# Altium schematic sheet schematic.SchDoc
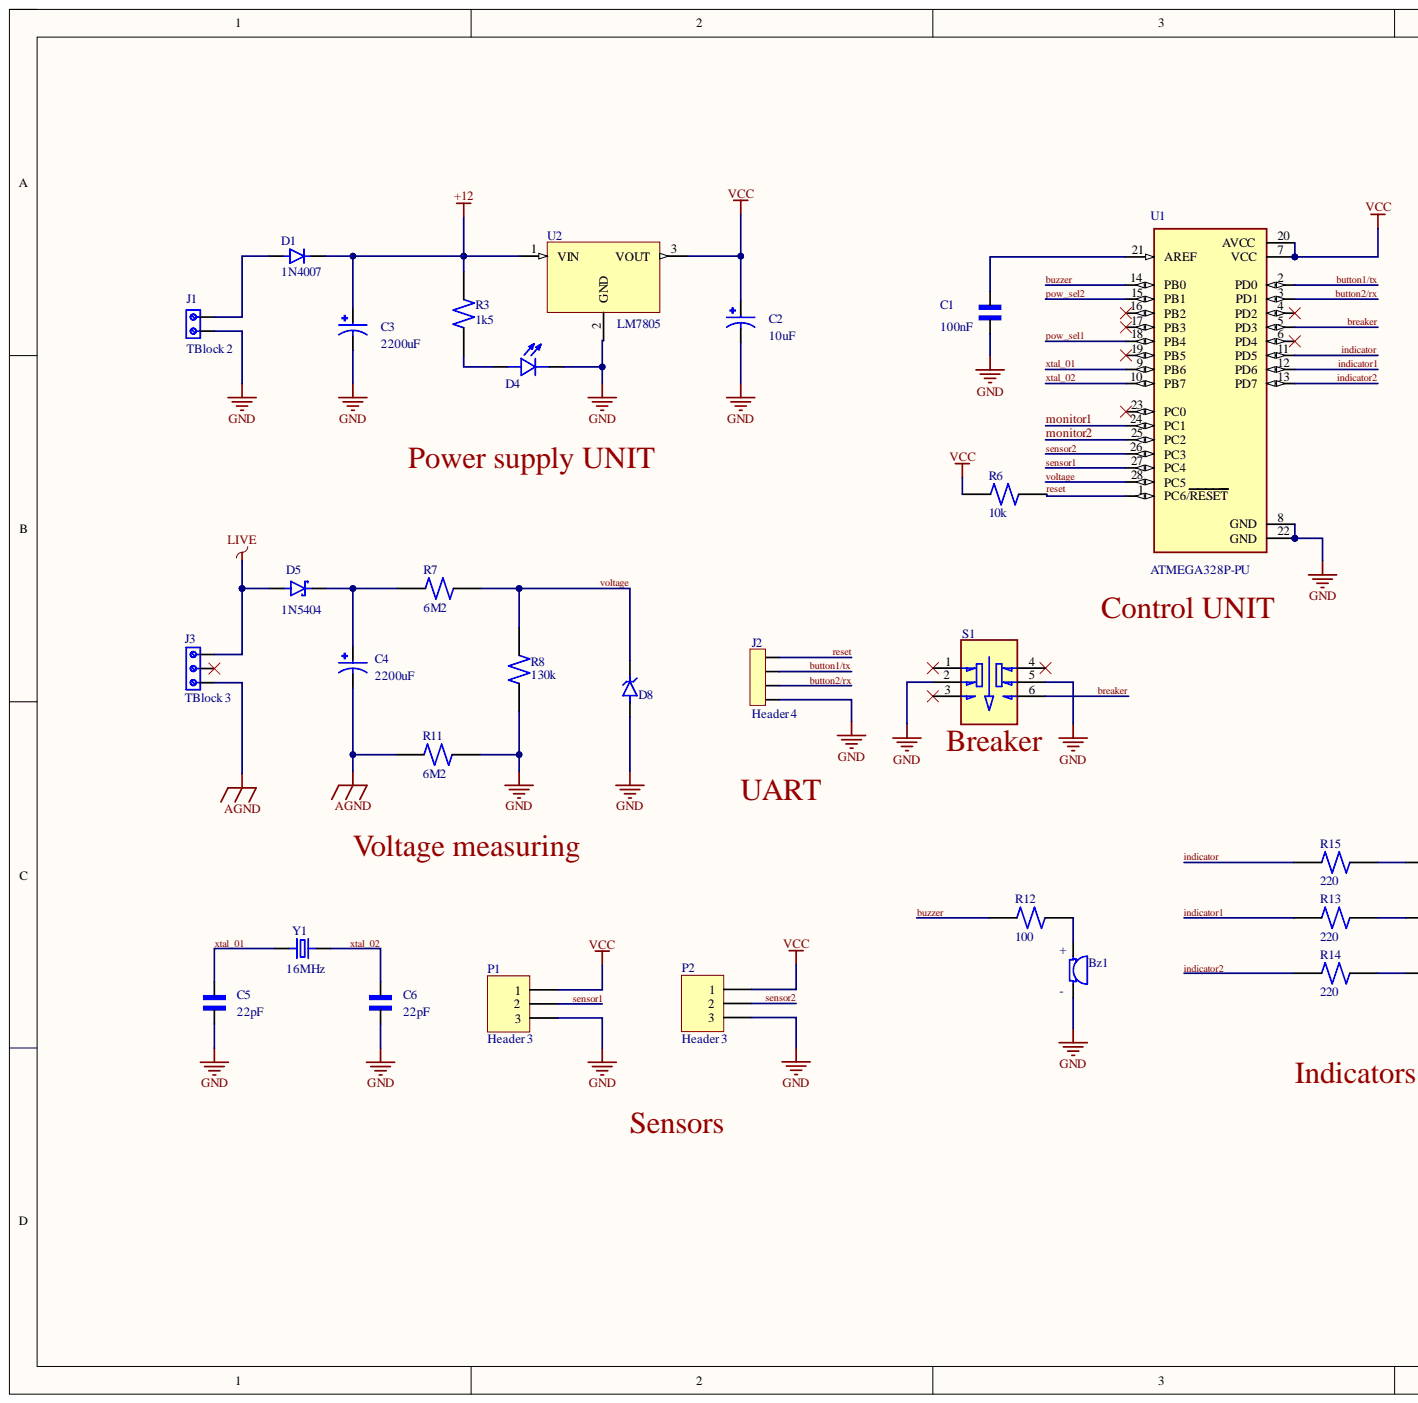
[diagram p0: schematic.SchDoc, left]
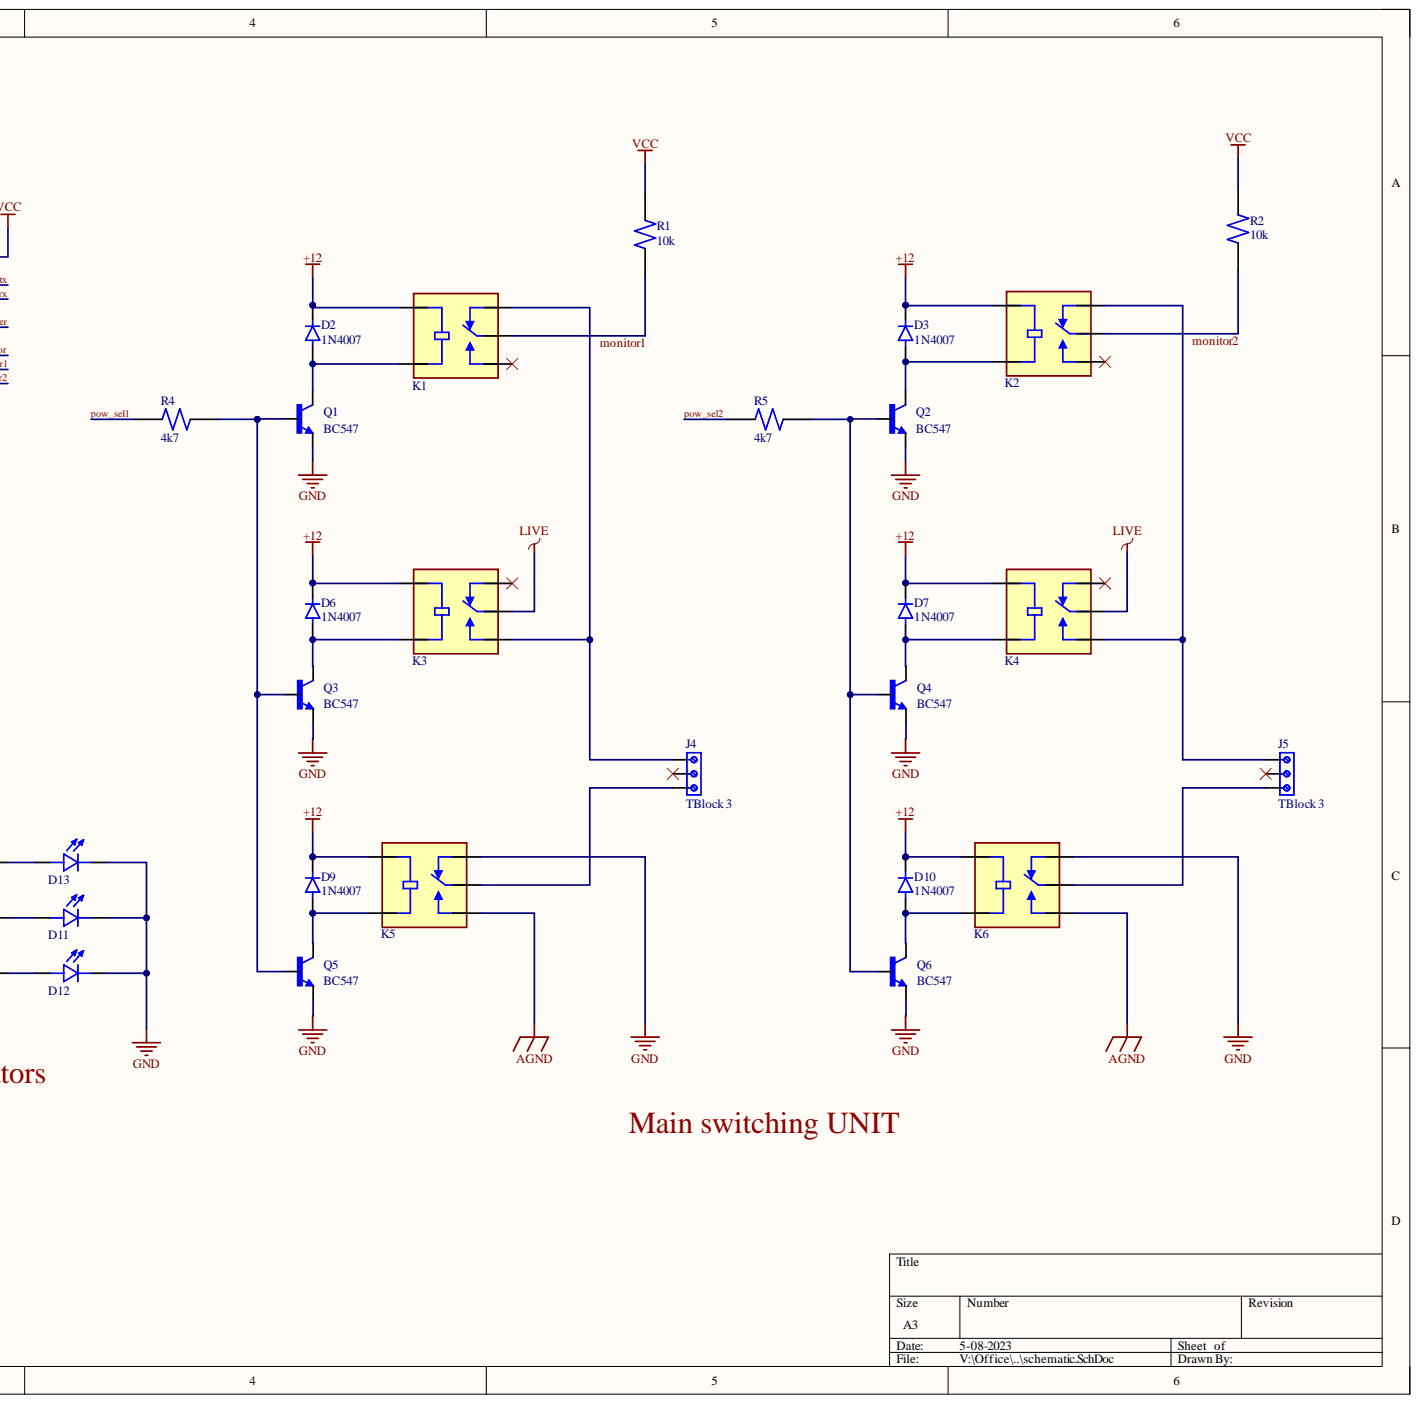
[diagram p1: schematic.SchDoc, right]

## Parts
Bz1  AI-1223-TWT-12V-R  [XDCR_AI-1223-TWT-12V-R]  (value not drawn)
C1  100nF  [CAPRB500W50L450T300H550]
C2  10uF  [CAPPRD500W50D500H1100]
C3  2200uF  [CAPPRD500W50D500H1100]
C4  2200uF  [CAPPRD750W80D1600H2500]
C5  22pF  [CAPRB500W50L450T300H550]
C6  22pF  [CAPRB500W50L450T300H550]
D1  1N4007  [DIOAD1060W80L520D270]
D2  1N4007  [DIOAD1060W80L520D270]
D3  1N4007  [DIOAD1060W80L520D270]
D4  L08R5000Q1  [LEDRD254W57D500H1070]  (value not drawn)
D5  1N5404  [DIOAD1990W125L900D530]
D6  1N4007  [DIOAD1060W80L520D270]
D7  1N4007  [DIOAD1060W80L520D270]
D8  1N4745A  [DIOAD1060W70L435D230]  (value not drawn)
D9  1N4007  [DIOAD1060W80L520D270]
D10  1N4007  [DIOAD1060W80L520D270]
D11  L08R5000Q1  [LEDRD254W57D500H1070]  (value not drawn)
D12  L08R5000Q1  [LEDRD254W57D500H1070]  (value not drawn)
D13  L08R5000Q1  [LEDRD254W57D500H1070]  (value not drawn)
J1  TBlock 2  [691111710002]
J2  Header 4  [SULLINS_PPTC041LFBN-RC]
J3  TBlock 3  [691214110003]
J4  TBlock 3  [691214110003]
J5  TBlock 3  [691214110003]
K1  JQC-3F-1C-24VDC  [RELAY_JQC-3F-1C-24VDC]  (value not drawn)
K2  JQC-3F-1C-24VDC  [RELAY_JQC-3F-1C-24VDC]  (value not drawn)
K3  JQC-3F-1C-24VDC  [RELAY_JQC-3F-1C-24VDC]  (value not drawn)
K4  JQC-3F-1C-24VDC  [RELAY_JQC-3F-1C-24VDC]  (value not drawn)
K5  JQC-3F-1C-24VDC  [RELAY_JQC-3F-1C-24VDC]  (value not drawn)
K6  JQC-3F-1C-24VDC  [RELAY_JQC-3F-1C-24VDC]  (value not drawn)
P1  Header 3  [HDR1X3]
P2  Header 3  [HDR1X3]
Q1  BC547  [TO92250P510H770-3]
Q2  BC547  [TO92250P510H770-3]
Q3  BC547  [TO92250P510H770-3]
Q4  BC547  [TO92250P510H770-3]
Q5  BC547  [TO92250P510H770-3]
Q6  BC547  [TO92250P510H770-3]
R1  10k  [RESAD1100W55L680D260]
R2  10k  [RESAD1100W55L680D260]
R3  1k5  [RESAD1100W55L680D260]
R4  4k7  [RESAD1100W55L680D260]
R5  4k7  [RESAD1100W55L680D260]
R6  10k  [RESAD1100W55L680D260]
R7  6M2  [RESAD1100W55L680D260]
R8  130k  [RESAD1100W55L680D260]
R11  6M2  [RESAD1100W55L680D260]
R12  100  [RESAD1100W55L680D260]
R13  220  [RESAD1100W55L680D260]
R14  220  [RESAD1100W55L680D260]
R15  220  [RESAD1100W55L680D260]
S1  TL2203EE  [SW_TL2203EE]  (value not drawn)
U1  ATMEGA328P-PU  [DIP794W46P254L2967H457Q28B]
U2  LM7805  [TO255P1020X450X1968-3]
Y1  16MHz  [XTAL_ECS-160-20-4X]

## Nets
+12: C3.P D1.C D2.C D3.C D6.C D7.C D9.C D10.C K1.COIL1 K2.COIL1 K3.COIL1 K4.COIL1 K5.COIL1 K6.COIL1 R3.2 U2.1
AGND: C4.N J3.1 K5.NO K6.NO R11.2
GND: Bz1.N C1.1 C2.N C3.N C5.1 C6.1 D4.C D8.A D11.C D12.C D13.C J1.1 J2.4 K5.NC K6.NC P1.3 P2.3 Q1.3 Q2.3 Q3.3 Q4.3 Q5.3 Q6.3 R8.1 R11.1 S1.2 S1.5 U1.8(GND) U1.22(GND) U2.2
LIVE: D5.A J3.3 K3.COM K4.COM
VCC: C2.P P1.1 P2.1 R1.2 R2.2 R6.2 U1.7(VCC) U1.20(AVCC) U2.3
breaker: S1.6 U1.5(PD3)
button1/tx: J2.2 U1.2(PD0)
button2/rx: J2.3 U1.3(PD1)
buzzer: R12.2 U1.14(PB0)
indicator: R15.2 U1.11(PD5)
indicator1: R13.2 U1.12(PD6)
indicator2: R14.2 U1.13(PD7)
monitor1: K1.COM R1.1 U1.24(PC1)
monitor2: K2.COM R2.1 U1.25(PC2)
pow_sel1: R4.2 U1.18(PB4)
pow_sel2: R5.2 U1.15(PB1)
reset: J2.1 R6.1 U1.1(PC6/RESET)
sensor1: P1.2 U1.27(PC4)
sensor2: P2.2 U1.26(PC3)
voltage: D8.C R7.1 R8.2 U1.28(PC5)
xtal_01: C5.2 U1.9(PB6) Y1.1
xtal_02: C6.2 U1.10(PB7) Y1.2
NetBz1_P: Bz1.P R12.1
NetC1_2: C1.2 U1.21(AREF)
NetC4_P: C4.P D5.C R7.2
NetD1_A: D1.A J1.2
NetD2_A: D2.A K1.COIL2 Q1.1
NetD3_A: D3.A K2.COIL2 Q2.1
NetD4_A: D4.A R3.1
NetD6_A: D6.A K3.COIL2 Q3.1
NetD7_A: D7.A K4.COIL2 Q4.1
NetD9_A: D9.A K5.COIL2 Q5.1
NetD10_A: D10.A K6.COIL2 Q6.1
NetD11_A: D11.A R13.1
NetD12_A: D12.A R14.1
NetD13_A: D13.A R15.1
NetJ4_1: J4.1 K1.NC K3.NO
NetJ4_3: J4.3 K5.COM
NetJ5_1: J5.1 K2.NC K4.NO
NetJ5_3: J5.3 K6.COM
NetQ1_2: Q1.2 Q3.2 Q5.2 R4.1
NetQ2_2: Q2.2 Q4.2 Q6.2 R5.1

Not connected: J3 2; J4 2; J5 2; K1 NO; K2 NO; K3 NC; K4 NC; S1 1 3 4; U1 4(PD2) 6(PD4) 16(PB2) 17(PB3) 19(PB5) 23(PC0)

## Library data of the parts
Bz1 = Buzzer: ELECTRO-MECHANICAL AUDIO INDICATOR; MF: PUI Audio,; Availability: In Stock; Component Kind: Standard; MP: AI-1223-TWT-12V-R; Package: 2225 PUI Audio; Price: None; Purchase-URL: https://pricing.snapeda.com/search/part/AI-1223-TWT-12V-R/?ref=eda; Library Name: Installed library.IntLib
C1 C5 C6 = Ceramic capacitor: 2200 pF Â±10% 250V Ceramic Capacitor X7R Radial; MF: TDK; MP: FG24X7R2E222KNT06; Check_prices: https://www.snapeda.com/parts/FG24X7R2E222KNT06/TDK/view-part/?ref=eda; Component Kind: Standard; Digi-Key_Part_Number: 445-173386-1-ND; Package: Radial TDK; Purchase-URL: https://pricing.snapeda.com/search/part/FG24X7R2E222KNT06/?ref=eda; SnapEDA_Link: https://www.snapeda.com/parts/FG24X7R2E222KNT06/TDK/view-part/?ref=snap; Library Name: Installed library.IntLib
C2 C3 = Electrolytic capacitor: FS-A; MF: {'manufacturer': u'Panasonic'}; MP: EEUFS0J221B; Availability: Unavailable; Component Kind: Standard; Package: None; Price: None; Library Name: Installed library.IntLib
C4 = Capacitor (16 x 25mm): Cap Aluminum 33uF 400V 20% (16 X 25mm) Radial Aluminum Cylindrical Can 7.5mm 275mA 2000 hr 85Â°C Bulk; MF: Panasonic; MP: ECA2GM330; Availability: In Stock; Check_prices: https://www.snapeda.com/parts/ECA2GM330/Panasonic/view-part/5790262/?ref=eda; Component Kind: Standard; Package: RADIAL-2 Panasonic; Price: None; Purchase-URL: https://pricing.snapeda.com/search/part/ECA2GM330/?ref=eda; SnapEDA_Link: https://www.snapeda.com/parts/ECA2GM330/Panasonic/view-part/5790262/?ref=snap; Library Name: Installed library.IntLib
D1-D3 D6 D7 D9 D10 = 1N4007: Diode Switching 1KV 1A 2-Pin DO-41; Availability: Unavailable; Component Kind: Standard; Library Name: Components.IntLib; MP: 1N4007; Package: None; Price: None
D4 D11-D13 = LED: LED, Orange, Through Hole, T-1 3/4 (5mm), 30 mA, 2.1 V, 635 nm; MF: {'manufacturer': u'LED Technology'}; Availability: Unavailable; Component Kind: Standard; MP: L08R5000Q1; Package: None; Price: None; Library Name: Installed library.IntLib
D5 = Schrotic diode: Diode Standard 400 V 3A Through Hole DO-201AD; MF: ON Semiconductor; Availability: In Stock; Check_prices: https://www.snapeda.com/parts/1N5404/Onsemi/view-part/?ref=eda; Component Kind: Standard; MP: 1N5404; Package: AXIAL LEAD-2 ON Semiconductor; Price: None; Purchase-URL: https://pricing.snapeda.com/search/part/1N5404/?ref=eda; SnapEDA_Link: https://www.snapeda.com/parts/1N5404/Onsemi/view-part/?ref=snap; Library Name: Installed library.IntLib
D8 = Zenner diode: Zener Diode 16V 1W Â±5% Through Hole DO-204AL (DO-41); MF: ON Semiconductor; Availability: In Stock; Check_prices: https://www.snapeda.com/parts/1N4745A/Onsemi/view-part/?ref=eda; Component Kind: Standard; MP: 1N4745A; Package: DO-41-2 ON Semiconductor; Price: None; Purchase-URL: https://pricing.snapeda.com/search/part/1N4745A/?ref=eda; SnapEDA_Link: https://www.snapeda.com/parts/1N4745A/Onsemi/view-part/?ref=snap; Library Name: Installed library.IntLib
J1 = Terminal Block - 2Pins: 2 Position Wire to Board Terminal Block Horizontal with Board 0.197 _5.00mm_ Through Hole; MF: Wurth Electronics; Availability: Unavailable; Component Kind: Standard; MP: 691111710002; Package: None; Price: None; SnapEDA_Link: https://www.snapeda.com/parts/691111710002/Wurth%20Electronics%20Inc./view-part/1195092/?ref=snap; Library Name: Installed library.IntLib
J2 = Female header - 4Pins: 4 Position Header Connector Through Hole; MF: Sullins Connector; MP: PPTC041LFBN-RC; Availability: Warning; Check_prices: https://www.snapeda.com/parts/PPTC041LFBN-RC/Sullins%20Connector%20Solutions/view-part/285917/?ref=eda; Component Kind: Standard; Package: None; Price: None; Purchase-URL: https://pricing.snapeda.com/search/part/PPTC041LFBN-RC/?ref=eda; SnapEDA_Link: https://www.snapeda.com/parts/PPTC041LFBN-RC/Sullins%20Connector%20Solutions/view-part/285917/?ref=snap; Library Name: Installed library.IntLib
J3-J5 = Terminal blocks - 3Pins (Small): 3 Position Wire to Board Terminal Block Horizontal with Board 0.138 (3.50mm) Through Hole; MF: Wurth Elektronik; Availability: In Stock; Check_prices: https://www.snapeda.com/parts/691214110003/Wurth+Elektronik/view-part/?ref=eda; Component Kind: Standard; MP: 691214110003; Package: None; Price: None; Purchase-URL: https://pricing.snapeda.com/search/part/691214110003/?ref=eda; SnapEDA_Link: https://www.snapeda.com/parts/691214110003/Wurth+Elektronik/view-part/?ref=snap; Library Name: Installed library.IntLib
K1-K6 = Relay (Standard): Miniature PCB Relay 24VDC, 10A 250VAC, 15A 125VAC, 10A 250VAC; Availability: Unavailable; Component Kind: Standard; MP: JQC-3F-1C-24VDC; Package: None; Price: None; Library Name: Installed library.IntLib
P1 P2 = Header 3: Header, 3-Pin; Component Kind: Standard; LatestRevisionDate: 17-Jul-2002; LatestRevisionNote: Re-released for DXP Platform.; Library Name: Miscellaneous Connectors.IntLib; Signal Integrity: Connector; Publisher: Altium Limited
Q1-Q6 = BC547B: <a href="https://pricing.snapeda.com/parts/BC337-16%20A1/Taiwan%20Semiconductor/view-part?ref=eda">Check availability</a> <a href="https://pricing.snapeda.com/parts/BC337-16%20A1/Taiwan%20Semiconductor/view-part?ref=eda">Check availability</a>; Availability: Unavailable; Component Kind: Standard; MP: BC547B; Package: None; Price: None; Library Name: Installed library.IntLib
R1-R8 R11-R15 = Resistor (0.25W): (MFR-25FBF52-10K) 10,2 kOhm bei 1% 0,25W, 1/4W Durchbohrlochwiderstand Axial Metal Film; MF: Yageo; Component Kind: Standard; Digi-Key_Part_Number: 10.2KXBK-ND; MP: MFR-25FBF52-10K; Package: Axial Yageo; Purchase-URL: https://pricing.snapeda.com/search/part/MFR-25FBF52-10K/?ref=eda; SnapEDA_Link: https://www.snapeda.com/parts/MFR-25FBF52-10K/Yageo/view-part/424333/?ref=snap; Library Name: Installed library.IntLib
S1 = Switch button: Pushbutton Switch DPDT Standard Through Hole; MF: E-Switch; Availability: In Stock; Check_prices: https://www.snapeda.com/parts/TL2203EE/E-Switch/view-part/?ref=eda; Component Kind: Standard; MP: TL2203EE; Package: None; Price: None; Purchase-URL: https://pricing.snapeda.com/search/part/TL2203EE/?ref=eda; SnapEDA_Link: https://www.snapeda.com/parts/TL2203EE/E-Switch/view-part/?ref=snap; Library Name: Installed library.IntLib
U1 = ATMEGA328P-PU: ATmega Series 20 MHz 32 KB Flash 2 KB SRAM 8-Bit Microcontroller - DIP-28; Availability: Unavailable; Component Kind: Standard; MP: ATMEGA328P-PU; Package: None; Price: None; Library Name: Installed library.IntLib
U2 = LM78__: MP: LM7805CT/NOPB; Availability: Unavailable; Component Kind: Standard; Library Name: Voltage regulator.IntLib; Package: None; Price: None
Y1 = Crystal oscilator: CRYSTAL T/H; MF: {'manufacturer': u'ECS Inc.'}; MP: ECS-160-S-4; Availability: Unavailable; Component Kind: Standard; Package: None; Price: None; Library Name: Installed library.IntLib
